# Altium schematic sheet POWER-VIN.SchDoc(POWER-VIN)
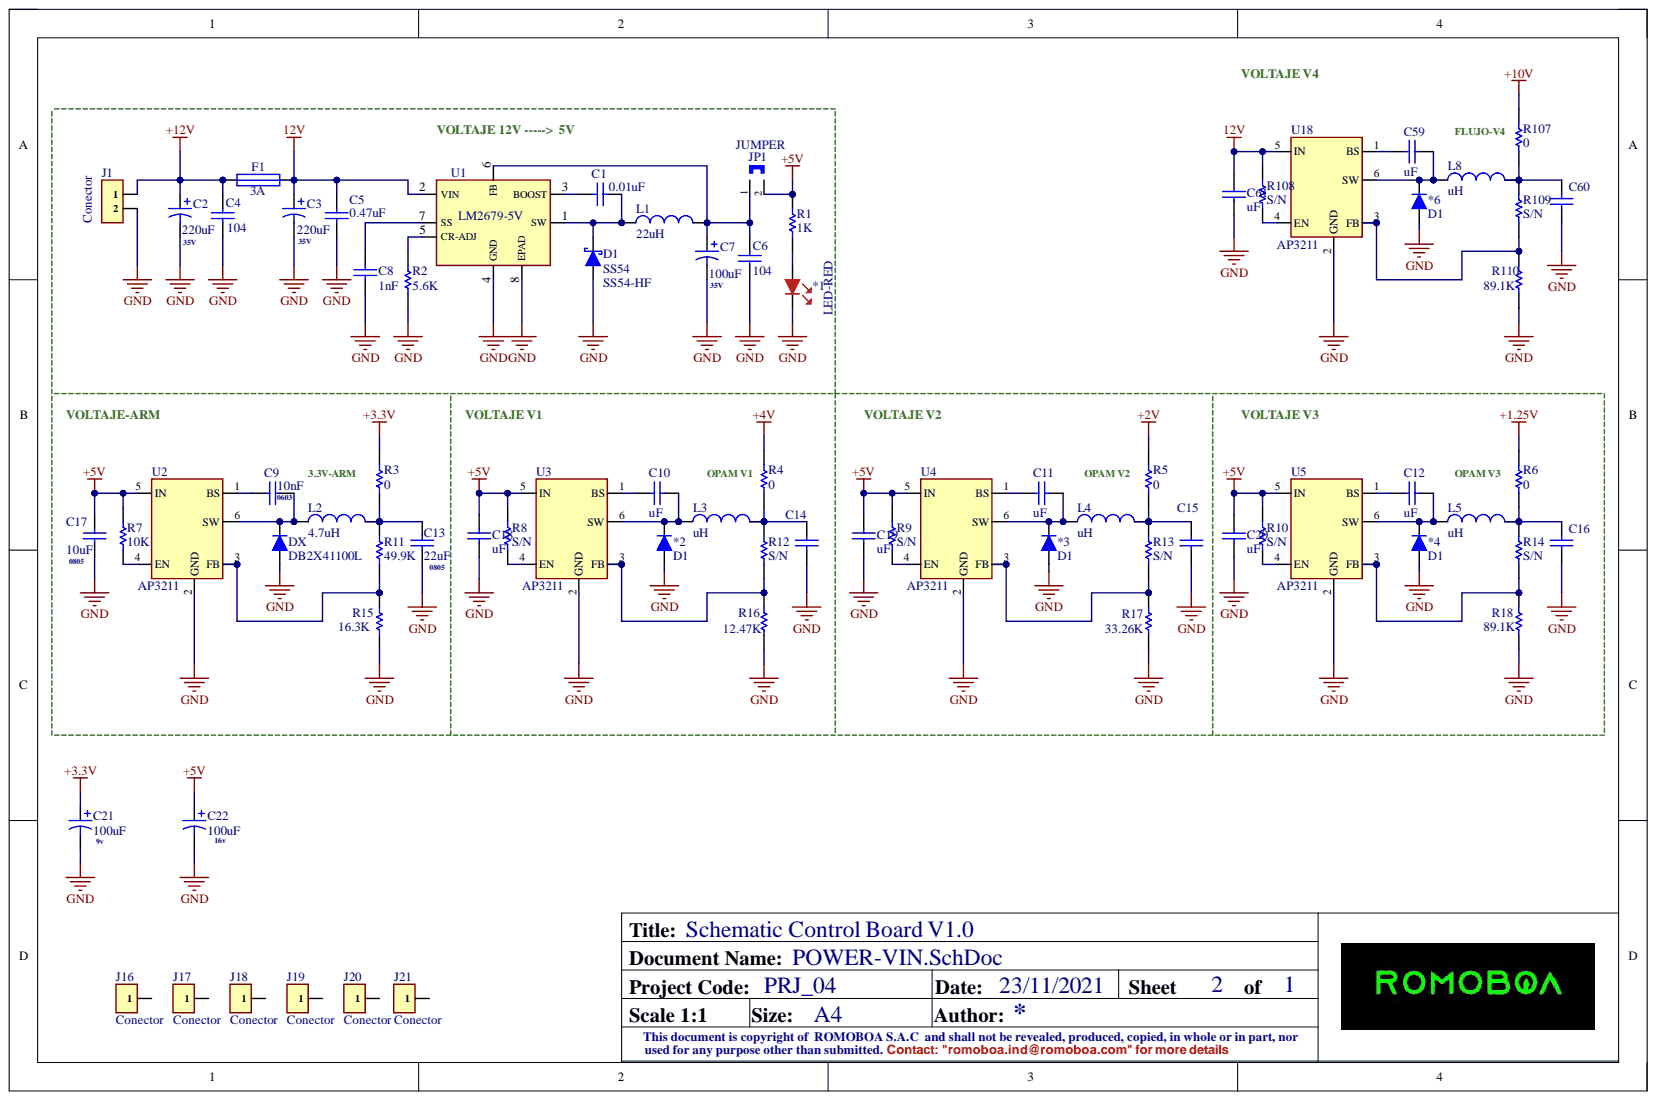
[diagram p0: POWER-VIN.SchDoc]

## Parts
*1  LED-RED  [LED 0805_2012 RED]
*2  D1  [1n4148 - SOD123]
*3  D1  [1n4148 - SOD123]
*4  D1  [1n4148 - SOD123]
*6  D1  [1n4148 - SOD123]
C1  0.01uF  [CAP1206]
C2  220uF  [CAPAE-D8.0x10.2]
C3  220uF  [CAPAE-D8.0x10.2]
C4  104  [CAP0805]
C5  0.47uF  [CAP0805]
C6  104  [CAP0805]
C7  100uF  [CAPAE-D8.0x10.2]
C8  1nF  [CAP0805]
C9  10nF  [CAP0805]
C10  uF  [CAP0805]
C11  uF  [CAP0805]
C12  uF  [CAP0805]
C13  22uF  [CAP0805]
C14  CAP_SMD_NP  [CAP0805]  (value not drawn)
C15  CAP_SMD_NP  [CAP0805]  (value not drawn)
C16  CAP_SMD_NP  [CAP0805]  (value not drawn)
C17  10uF  [CAP0805]
C18  uF  [CAP0805]
C19  uF  [CAP0805]
C20  uF  [CAP0805]
C21  100uF  [CAPAE6.6x6.6X55mm]
C22  100uF  [CAPAE6.6x6.6X55mm]
C59  uF  [CAP0805]
C60  CAP_SMD_NP  [CAP0805]  (value not drawn)
C61  uF  [CAP0805]
D1  SS54  Manufacturer Part Number: SS54-HF  [DIODE: SMC]
DX  DB2X41100L  [1n4148 - SOD123]
F1  3A  [FUSE: 0679H (BelFuse)]
J1  Conector  [molex2x1-3.96mm]
J16  Conector  [CON: TH 3mm - COR]
J17  Conector  [CON: TH 3mm - COR]
J18  Conector  [CON: TH 3mm - COR]
J19  Conector  [CON: TH 3mm - COR]
J20  Conector  [CON: TH 3mm - COR]
J21  Conector  [CON: TH 3mm - COR]
JP1  JUMPER  [1x2x2.54 Pin Header TH V]
L1  22uH  [DR-127]
L2  4.7uH  [L1210-LQH]
L3  uH  [L1210-LQH]
L4  uH  [L1210-LQH]
L5  uH  [L1210-LQH]
L8  uH  [L1210-LQH]
R1  1K  [RES: 0805R]
R2  5.6K  [RES: 0805R]
R3  0  [RES: 0603]
R4  0  [RES: 0603]
R5  0  [RES: 0603]
R6  0  [RES: 0603]
R7  10K  [RES: 0805R]
R8  S/N  [RES: 0805R]
R9  S/N  [RES: 0805R]
R10  S/N  [RES: 0805R]
R11  49.9K  [RES: 0805R]
R12  S/N  [RES: 0805R]
R13  S/N  [RES: 0805R]
R14  S/N  [RES: 0805R]
R15  16.3K  [RES: 0805R]
R16  12.47K  [RES: 0805R]
R17  33.26K  [RES: 0805R]
R18  89.1K  [RES: 0805R]
R107  0  [RES: 0603]
R108  S/N  [RES: 0805R]
R109  S/N  [RES: 0805R]
R110  89.1K  [RES: 0805R]
U1  LM2679-5V  [TO-263-7_LM2679]
U2  AP3211  [SOT-23-6_L2.9-W1.6-P0.95-LS2.8-BR]
U3  AP3211  [SOT-23-6_L2.9-W1.6-P0.95-LS2.8-BR]
U4  AP3211  [SOT-23-6_L2.9-W1.6-P0.95-LS2.8-BR]
U5  AP3211  [SOT-23-6_L2.9-W1.6-P0.95-LS2.8-BR]
U18  AP3211  [SOT-23-6_L2.9-W1.6-P0.95-LS2.8-BR]

## Nets
12V: C2.1 C3.1 C4.2 C5.2 C61.1 F1.1 F1.2 J1.1 R108.2 U1.2(VIN) U18.5(IN)
+1.25V: R6.2
+2V: R5.2
+3.3V: C21.1 R3.2
+4V: R4.2
+5V: C17.1 C18.1 C19.1 C20.1 C22.1 JP1.2 R1.2 R7.2 R8.2 R9.2 R10.2 U2.5(IN) U3.5(IN) U4.5(IN) U5.5(IN)
+10V: R107.2
GND: *1.2 *2.1 *3.1 *4.1 *6.1 C2.2 C3.2 C4.1 C5.1 C6.1 C7.2 C8.1 C13.1 C14.1 C15.1 C16.1 C17.2 C18.2 C19.2 C20.2 C21.2 C22.2 C60.1 C61.2 D1.1 DX.1 J1.2 R2.1 R15.1 R16.1 R17.1 R18.1 R110.1 U1.4(GND) U1.8(EPAD) U2.2(GND) U3.2(GND) U4.2(GND) U5.2(GND) U18.2(GND)
Net*1_1: *1.1 R1.1
Net*2_2: *2.2 C10.1 L3.1 U3.6(SW)
Net*3_2: *3.2 C11.1 L4.1 U4.6(SW)
Net*4_2: *4.2 C12.1 L5.1 U5.6(SW)
Net*6_2: *6.2 C59.1 L8.1 U18.6(SW)
NetC1_1: C1.1 D1.2 L1.1 U1.1(SW)
NetC1_2: C1.2 U1.3(BOOST)
NetC6_2: C6.2 C7.1 JP1.1 L1.2 U1.6(FB)
NetC8_2: C8.2 U1.7(SS)
NetC9_1: C9.1 DX.2 L2.1 U2.6(SW)
NetC9_2: C9.2 U2.1(BS)
NetC10_2: C10.2 U3.1(BS)
NetC11_2: C11.2 U4.1(BS)
NetC12_2: C12.2 U5.1(BS)
NetC13_2: C13.2 L2.2 R3.1 R11.2
NetC14_2: C14.2 L3.2 R4.1 R12.2
NetC15_2: C15.2 L4.2 R5.1 R13.2
NetC16_2: C16.2 L5.2 R6.1 R14.2
NetC59_2: C59.2 U18.1(BS)
NetC60_2: C60.2 L8.2 R107.1 R109.2
NetR2_2: R2.2 U1.5(CR-ADJ)
NetR7_1: R7.1 U2.4(EN)
NetR8_1: R8.1 U3.4(EN)
NetR9_1: R9.1 U4.4(EN)
NetR10_1: R10.1 U5.4(EN)
NetR11_1: R11.1 R15.2 U2.3(FB)
NetR12_1: R12.1 R16.2 U3.3(FB)
NetR13_1: R13.1 R17.2 U4.3(FB)
NetR14_1: R14.1 R18.2 U5.3(FB)
NetR108_1: R108.1 U18.4(EN)
NetR109_1: R109.1 R110.2 U18.3(FB)

Not connected: J16 1; J17 1; J18 1; J19 1; J20 1; J21 1

## Library data of the parts
*2-*4 *6 DX = 1N4148: Diode Configuration:Single; Repetitive Reverse Voltage Vrrm Max:75V;200mA;; Manufacturer: NXP Semiconductors; Capacitance: 4pF; Current: 4A; Element Configuration: Single; Forward Voltage: 1V; Max Power Dissipation: 500mW; Max Repetitive Reverse Voltage (Vrrm): 100V; Polarity: Standard; Reverse Recovery Time: 4ns; Case/Package: SOD; Category: Rectifier Diodes; Component Kind: Standard; ComponentLink1Description: https://datasheet.ciiva.com/1179/1720485-1179297.pdf?src-supplier=Element14; ComponentLink1URL: https://datasheet.ciiva.com/1179/1720485-1179297.pdf?src-supplier=Element14; ComponentLink2Description: https://datasheet.ciiva.com/26921/getdatasheetpartid-764103-26921186.pdf?src-supplier=Verical; ComponentLink2URL: https://datasheet.ciiva.com/26921/getdatasheetpartid-764103-26921186.pdf?src-supplier=Verical; Current Rating: 200mA; Forward Current: 200mA; Height: 0.25inch; Lead Free: Lead Free; Length: 2.5inch; Library Name: DIODE.IntLib; Manufacturer Part Number: 1N4148; Max Forward Surge Current (Ifsm): 4A; Max Operating Temperature: 200°C; Min Operating Temperature: -65°C; Number of Pins: 2; Output Current: 200mA; Packaging: Ammo Pack; Peak Non-Repetitive Surge Current: 4A; Pricing 1: 50=0.01310, 500=0.00960, 1500=0.00900, 5000=0.00830, 25000=0.00800, 50000=0.00790, 50=0.01260, 500=0.00920, 1500=0.00860, 5000=0.00800, 25000=0.00770, 50000=0.00760, 50=0.06530, 500=0.04780, 1500=0.04460, 5000=0.04140, 25000=0.04000, 50000=0.03930, 50=0.06000, 500=0.04390, 1500=0.04100, 5000=0.03800, 25000=0.03670, 50000=0.03610, 50=0.00810, 500=0.00590, 1500=0.00550, 5000=0.00510, 25000=0.00490, 50000=0.00480, 50=0.00750, 500=0.00550, 1500=0.00510, 5000=0.00470, 25000=0.00460, 50000=0.00450, 50=0.07410, 500=0.05420, 1500=0.05060, 5000=0.04690, 25000=0.04530, 50000=0.04450, 50=1.01450, 500=0.74290, 1500=0.69290, 5000=0.64300, 25000=0.62090, 50000=0.60990, 50=0.03970, 500=0.02910, 1500=0.02710, 5000=0.02520, 25000=0.02430, 50000=0.02390, 50=0.71580, 500=0.52410, 1500=0.48880, 5000=0.45360, 25000=0.43810, 50000=0.43030, 50=0.08380, 500=0.06130, 1500=0.05720, 5000=0.05310, 25000=0.05130, 50000=0.05030, 50=0.01310, 500=0.00960, 1500=0.00890, 5000=0.00830, 25000=0.00800, 50000=0.00790, 50=0.00956, 500=0.00700, 1500=0.00653, 5000=0.00606, 25000=0.00585, 50000=0.00575 (AUD); Radiation Hardening: No; REACH SVHC: No SVHC; RoHS: Yes; Stock 1: 62500; Supplier 1: LCSC; Supplier Part Number 1: C258182; Termination: Axial; Voltage: 100V; Voltage Rating (DC): 100V; Weight: 0.01lb; Width: 0.25inch
C1 C4-C6 C8-C20 C59-C61 = CAP_SMD_NP: CAPACITOR SMD NO POLARIZADO; Component Kind: Standard; Library Name: CAPACITORES.IntLib
C2 C3 C7 C21 C22 = CAP_SMD_P: CAPACITOR SMD POLARIZADO; Component Kind: Standard; Library Name: CAPACITORES.IntLib
D1 = SS54: DIODE SCHOTTKY 5A 40V SMC; Manufacturer: Comchip; Component Kind: Standard; ComponentLink1Description: New link; ComponentLink1URL: https://lcsc.com/product-detail/Schottky-Barrier-Diodes-SBD_MDD-Microdiode-Electronics-SS54_C16103.html; Library Name: DIODE.IntLib; Pricing 1: 1=0.40000, 10=0.26500, 100=0.16200, 1000=0.10700, 5000=0.09200, 10000=0.08600 (USD); Stock 1: 5021; Supplier 1: Mouser; Supplier Part Number 1: 750-SS54-HF
F1 = FUSE1: FUSE; Component Kind: Standard; Library Name: FUSE-VARISTOR.IntLib
J1 = HEADER 1X2: HEADER  THT 2.54MM; Component Kind: Standard; Library Name: CONECTORES-HEADER.IntLib
J16-J21 = HEADER 1X1: HEADER  THT 2.54MM; Component Kind: Standard; Library Name: CONECTORES-HEADER.IntLib
JP1 = JUMPER: JUMPER-HEADER; Component Kind: Standard; Library Name: CONECTORES-HEADER.IntLib
L1-L5 L8 = INDUCTOR_SMD: Component Kind: Standard; Library Name: INDUCTORES.IntLib
R1-R18 R107-R110 = Res1-SMD: Component Kind: Standard; Library Name: RESISTOR-POT..IntLib
U1 = LM2679-5V: IC REG BUCK ADJ 5A TO263-7; Manufacturer: TI National Semiconductor; Manufacturer Part Number: LM2679S-ADJ/NOPB; Efficiency: 84%; Max Input Voltage: 40V; Max Output Voltage: 37V; Min Input Voltage: 8V; Min Output Voltage: 1.21V; Number of Outputs: 1; Output Configuration: Positive; Output Type: Adjustable; Quiescent Current: 4.2mA; Switching Frequency: 260kHz; Synchronous Rectifier: No; Case/Package: TO-263; Category: Voltage Regulators - Switching; Component Kind: Standard; ComponentLink1Description: https://datasheet.ciiva.com/22684/1771438-22684602.pdf?src-supplier=Element14; ComponentLink1URL: https://datasheet.ciiva.com/22684/1771438-22684602.pdf?src-supplier=Element14; ComponentLink2Description: https://datasheet.ciiva.com/27051/1300283-27051902.pdf?src-supplier=Jameco; ComponentLink2URL: https://datasheet.ciiva.com/27051/1300283-27051902.pdf?src-supplier=Jameco; ComponentLink3Description: https://datasheet.ciiva.com/6596/lm2679-6596092.pdf?src-supplier=Digi-Key; ComponentLink3URL: https://datasheet.ciiva.com/6596/lm2679-6596092.pdf?src-supplier=Digi-Key; ComponentLink4Description: https://datasheet.ciiva.com/14150/lm2679-eng-tds-14150637.pdf?src-supplier=Distrelec; ComponentLink4URL: https://datasheet.ciiva.com/14150/lm2679-eng-tds-14150637.pdf?src-supplier=Distrelec; Height: 4.57mm; Lead Free: Contains Lead; Length: 10.16mm; Max Operating Temperature: 125°C; Max Output Current: 5A; Min Operating Temperature: -40°C; Mount: Surface Mount; Number of Pins: 7; Operating Supply Voltage: 40V; Output Current: 5A; Output Voltage: 37V; Package Quantity: 45; Packaging: Rail/Tube; Pricing 1: 1=11.79090, 10=10.28610, 30=10.01040, 100=9.73460, 500=9.61310, 1000=9.46120, 1=11.58490, 10=10.10640, 30=9.83550, 100=9.56450, 500=9.44510, 1000=9.29590, 1=58.70570, 10=51.21340, 30=49.84050, 100=48.46760, 500=47.86250, 1000=47.10640, 1=56.11690, 10=48.95510, 30=47.64270, 100=46.33030, 500=45.75190, 1000=45.02910, 1=7.54490, 10=6.58200, 30=6.40550, 100=6.22910, 500=6.15130, 1000=6.05410, 1=6.61580, 10=5.77150, 30=5.61680, 100=5.46200, 500=5.39380, 1000=5.30860, 1=70.54170, 10=61.53890, 30=59.88920, 100=58.23950, 500=57.51240, 1000=56.60380, 1=956.60220, 10=834.51700, 30=812.14570, 100=789.77450, 500=779.91350, 1000=767.59250, 1=36.95720, 10=32.24060, 30=31.37630, 100=30.51200, 500=30.13110, 1000=29.65500, 1=677.00000, 10=590.59870, 30=574.76630, 100=558.93380, 500=551.95510, 1000=543.23530, 1=76.28000, 10=66.54490, 30=64.76100, 100=62.97710, 500=62.19070, 1000=61.20830, 1=12.11860, 10=10.57200, 30=10.28860, 100=10.00510, 500=9.88020, 1000=9.72410, 1=9.09940, 10=7.93810, 30=7.72530, 100=7.51250, 500=7.41870, 1000=7.30150 (AUD); Radiation Hardening: No; REACH SVHC: No SVHC; RoHS: Yes; RoHS Compliant: Yes; Series: SIMPLE SWITCHER®; Stock 1: 14; Supplier 1: LCSC; Supplier Part Number 1: C188674; Weight: 0.01lb; Width: 8.64mm; Library Name: REGULADORES.IntLib
U2-U5 U18 = AP3211: Step-Down 18V 1.5A SOT-23-6 DC-DC; Component Kind: Standard; ComponentLink1Description: DATASHEET; ComponentLink1URL: https://lcsc.com/product-detail/DC-DC-Converters_Diodes-Incorporated-AP3211KTR-G1_C154863.html; Library Name: REGULADORES.IntLib
*1 = LED-RED: Component Kind: Standard; Library Name: LEDS.IntLib
